# Altium schematic sheet IDT7204.SchDoc
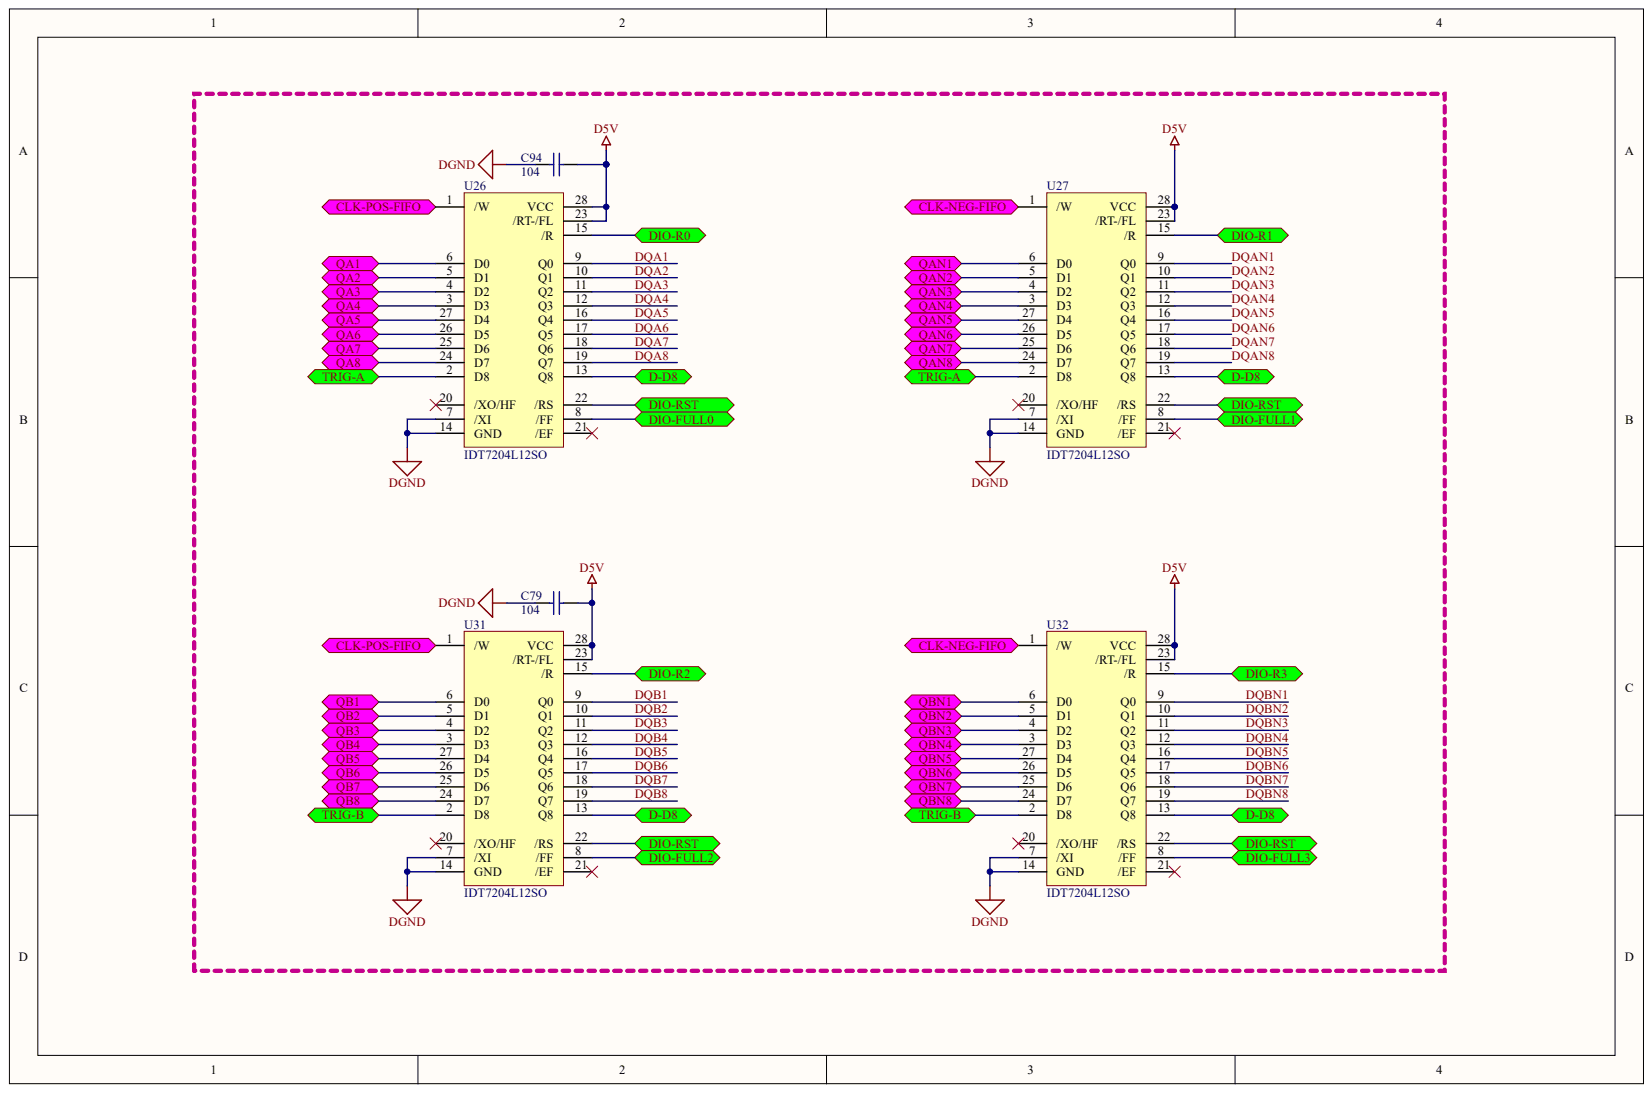
[diagram p0: IDT7204.SchDoc]

## Parts
C79  C104  (value not drawn)
C94  C104  (value not drawn)
U26  IDT7204L12SO
U27  IDT7204L12SO
U31  IDT7204L12SO
U32  IDT7204L12SO

## Ports
CLK-NEG-FIFO, CLK-POS-FIFO, D-D8, DIO-FULL0, DIO-FULL1, DIO-FULL2, DIO-FULL3, DIO-R0, DIO-R1, DIO-R2, DIO-R3, DIO-RST, QA1, QA2, QA3, QA4, QA5, QA6, QA7, QA8, QAN1, QAN2, QAN3, QAN4, QAN5, QAN6, QAN7, QAN8, QB1, QB2, QB3, QB4, QB5, QB6, QB7, QB8, QBN1, QBN2, QBN3, QBN4, QBN5, QBN6, QBN7, QBN8, TRIG-A, TRIG-B

## Nets
D5V: C79.1 C94.1 U26.23(/RT-/FL) U26.28(VCC) U27.23(/RT-/FL) U27.28(VCC) U31.23(/RT-/FL) U31.28(VCC) U32.23(/RT-/FL) U32.28(VCC)
DGND: C79.2 C94.2 U26.7(/XI) U26.14(GND) U27.7(/XI) U27.14(GND) U31.7(/XI) U31.14(GND) U32.7(/XI) U32.14(GND)
CLK-NEG-FIFO: U27.1(/W) U32.1(/W) ; port CLK-NEG-FIFO
CLK-POS-FIFO: U26.1(/W) U31.1(/W) ; port CLK-POS-FIFO
D-D8: U26.13(Q8) U27.13(Q8) U31.13(Q8) U32.13(Q8) ; port D-D8
DIO-FULL0: U26.8(/FF) ; port DIO-FULL0
DIO-FULL1: U27.8(/FF) ; port DIO-FULL1
DIO-FULL2: U31.8(/FF) ; port DIO-FULL2
DIO-FULL3: U32.8(/FF) ; port DIO-FULL3
DIO-R0: U26.15(/R) ; port DIO-R0
DIO-R1: U27.15(/R) ; port DIO-R1
DIO-R2: U31.15(/R) ; port DIO-R2
DIO-R3: U32.15(/R) ; port DIO-R3
DIO-RST: U26.22(/RS) U27.22(/RS) U31.22(/RS) U32.22(/RS) ; port DIO-RST
DQA1: U26.9(Q0)
DQA2: U26.10(Q1)
DQA3: U26.11(Q2)
DQA4: U26.12(Q3)
DQA5: U26.16(Q4)
DQA6: U26.17(Q5)
DQA7: U26.18(Q6)
DQA8: U26.19(Q7)
DQAN1: U27.9(Q0)
DQAN2: U27.10(Q1)
DQAN3: U27.11(Q2)
DQAN4: U27.12(Q3)
DQAN5: U27.16(Q4)
DQAN6: U27.17(Q5)
DQAN7: U27.18(Q6)
DQAN8: U27.19(Q7)
DQB1: U31.9(Q0)
DQB2: U31.10(Q1)
DQB3: U31.11(Q2)
DQB4: U31.12(Q3)
DQB5: U31.16(Q4)
DQB6: U31.17(Q5)
DQB7: U31.18(Q6)
DQB8: U31.19(Q7)
DQBN1: U32.9(Q0)
DQBN2: U32.10(Q1)
DQBN3: U32.11(Q2)
DQBN4: U32.12(Q3)
DQBN5: U32.16(Q4)
DQBN6: U32.17(Q5)
DQBN7: U32.18(Q6)
DQBN8: U32.19(Q7)
QA1: U26.6(D0) ; port QA1
QA2: U26.5(D1) ; port QA2
QA3: U26.4(D2) ; port QA3
QA4: U26.3(D3) ; port QA4
QA5: U26.27(D4) ; port QA5
QA6: U26.26(D5) ; port QA6
QA7: U26.25(D6) ; port QA7
QA8: U26.24(D7) ; port QA8
QAN1: U27.6(D0) ; port QAN1
QAN2: U27.5(D1) ; port QAN2
QAN3: U27.4(D2) ; port QAN3
QAN4: U27.3(D3) ; port QAN4
QAN5: U27.27(D4) ; port QAN5
QAN6: U27.26(D5) ; port QAN6
QAN7: U27.25(D6) ; port QAN7
QAN8: U27.24(D7) ; port QAN8
QB1: U31.6(D0) ; port QB1
QB2: U31.5(D1) ; port QB2
QB3: U31.4(D2) ; port QB3
QB4: U31.3(D3) ; port QB4
QB5: U31.27(D4) ; port QB5
QB6: U31.26(D5) ; port QB6
QB7: U31.25(D6) ; port QB7
QB8: U31.24(D7) ; port QB8
QBN1: U32.6(D0) ; port QBN1
QBN2: U32.5(D1) ; port QBN2
QBN3: U32.4(D2) ; port QBN3
QBN4: U32.3(D3) ; port QBN4
QBN5: U32.27(D4) ; port QBN5
QBN6: U32.26(D5) ; port QBN6
QBN7: U32.25(D6) ; port QBN7
QBN8: U32.24(D7) ; port QBN8
TRIG-A: U26.2(D8) U27.2(D8) ; port TRIG-A
TRIG-B: U31.2(D8) U32.2(D8) ; port TRIG-B

Not connected: U26 20(/XO/HF) 21(/EF); U27 20(/XO/HF) 21(/EF); U31 20(/XO/HF) 21(/EF); U32 20(/XO/HF) 21(/EF)

## Library data of the parts
C79 C94 U26 U27 U31 U32 = (no symbol name)
  C79 C94: : 104
